# Altium schematic sheet 07 - BANK 0, BANK13, JTAG.SchDoc("07 - BANK 0, BANK13, JTAG")
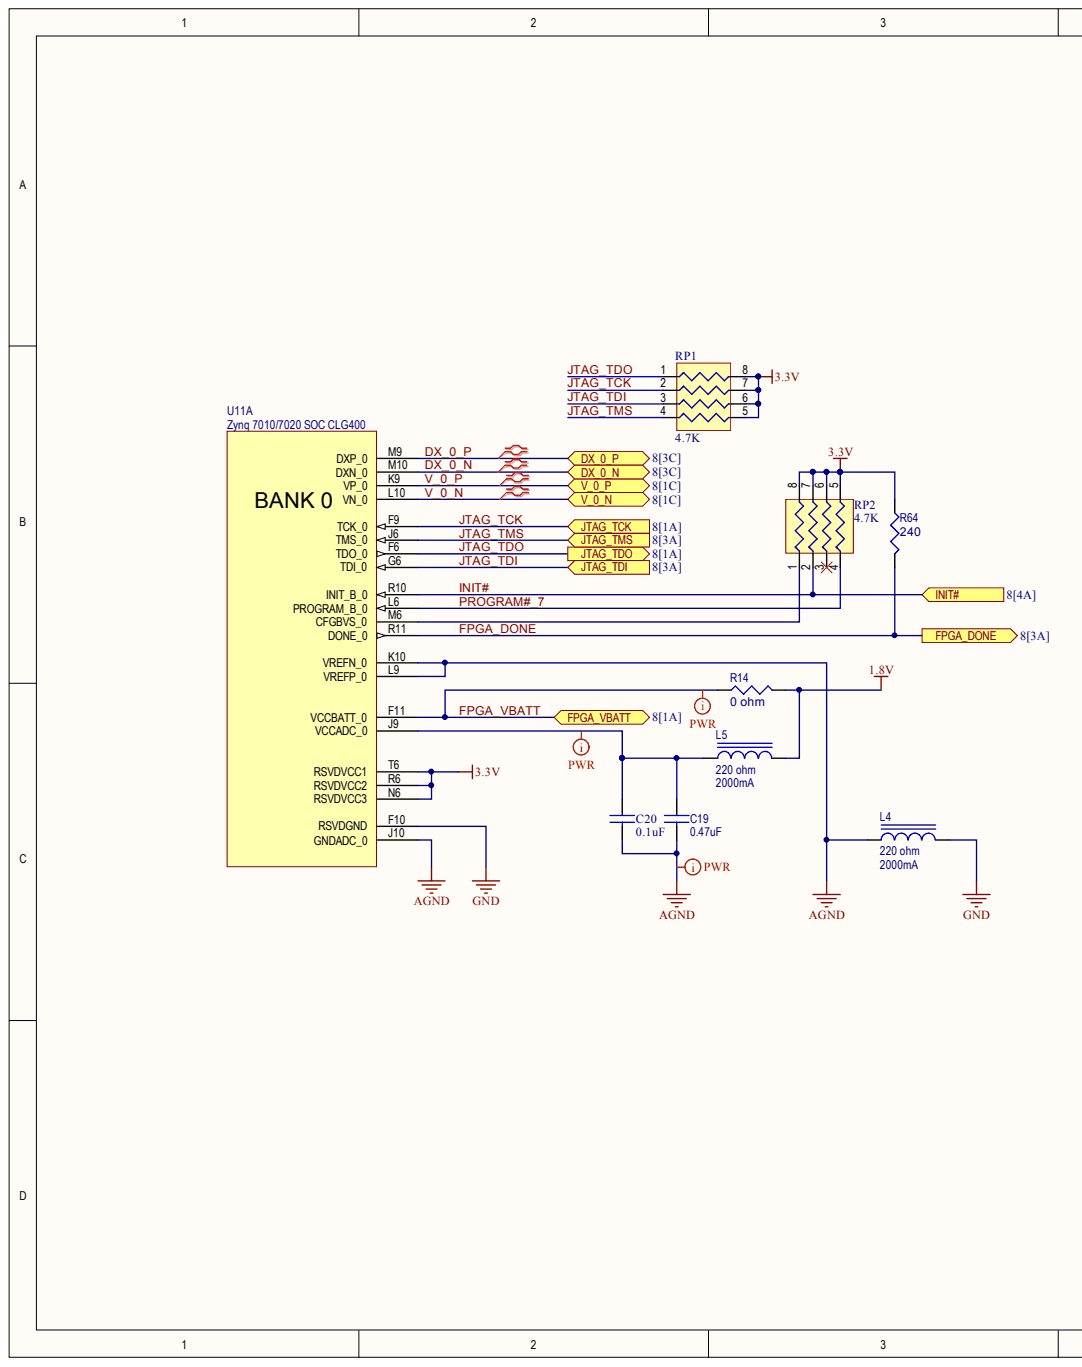
[diagram p0: 07 - BANK 0, BANK13, JTAG.SchDoc, left]
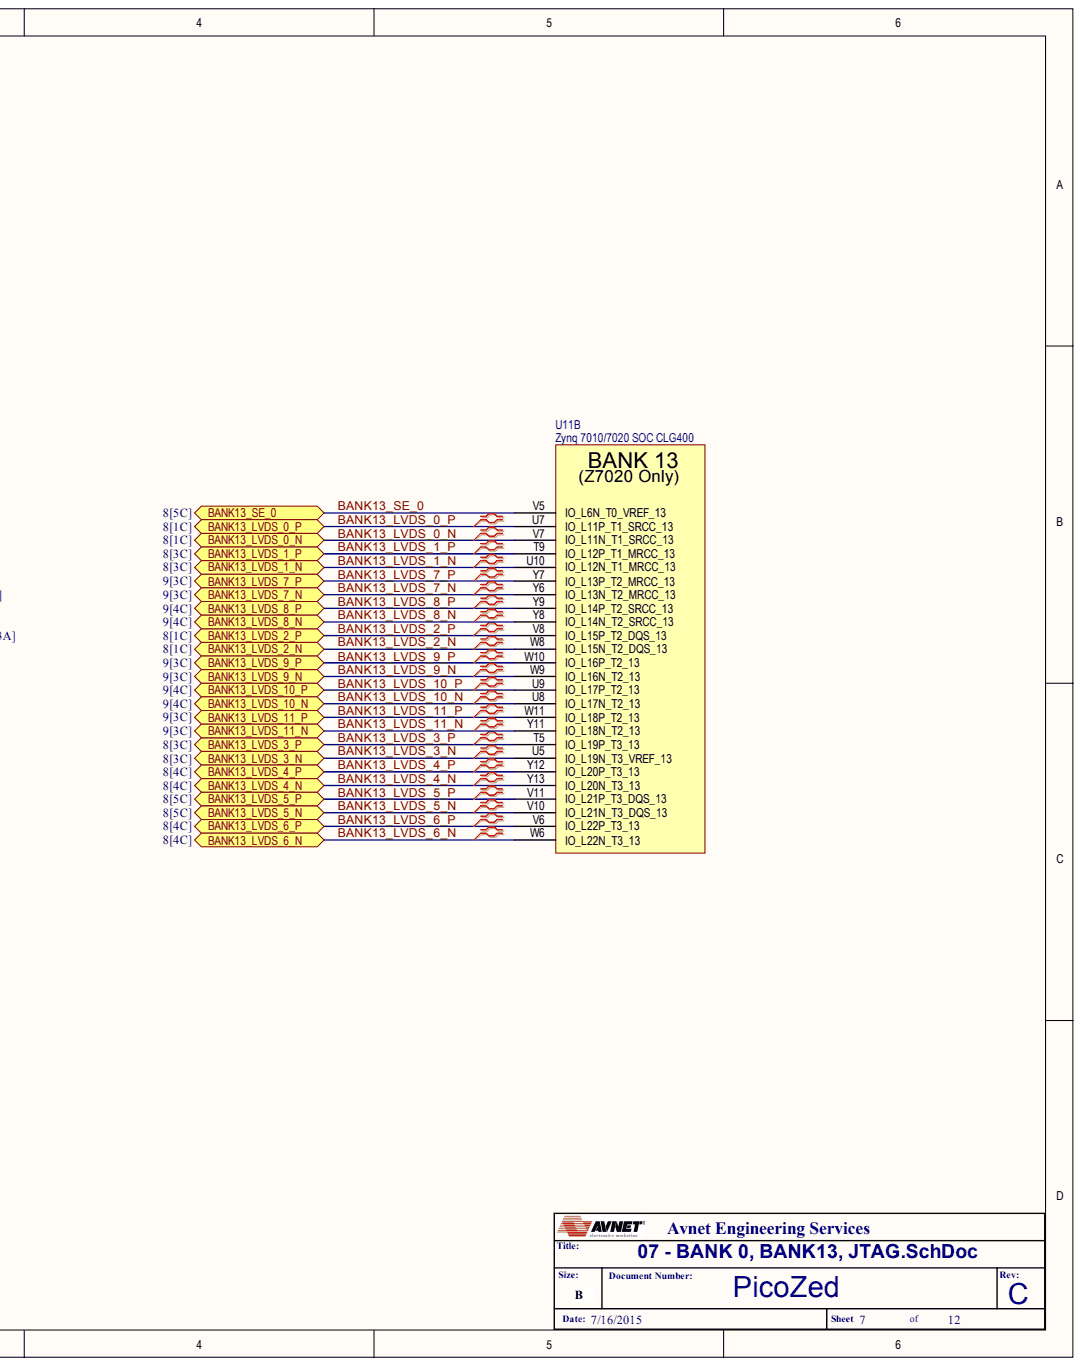
[diagram p1: 07 - BANK 0, BANK13, JTAG.SchDoc, right]

## Parts
C19  value 0.47uF  [C0402]  (hidden comment: PC475)
C20  value 0.1uF  [C0201]  (hidden comment: PC522)
L4  value 220 ohm  Max I: 2000mA  [L0805]  (hidden comment: PF009)
L5  value 220 ohm  Max I: 2000mA  [L0805]  (hidden comment: PF009)
R14  0 ohm  [R0402]
R64  240  [R0402]
RP1  value 4.7K  [RPACK4-LCC]  (hidden comment: PR010)
RP2  value 4.7K  [RPACK4-LCC]  (hidden comment: PR010)
U11  Zynq 7010/7020 SOC CLG400  [BGA400C80P20X20_1700X1700X160]  (parts A,B)

## Ports
BANK13_LVDS_0_N, BANK13_LVDS_0_P, BANK13_LVDS_1_N, BANK13_LVDS_1_P, BANK13_LVDS_2_N, BANK13_LVDS_2_P, BANK13_LVDS_3_N, BANK13_LVDS_3_P, BANK13_LVDS_4_N, BANK13_LVDS_4_P, BANK13_LVDS_5_N, BANK13_LVDS_5_P, BANK13_LVDS_6_N, BANK13_LVDS_6_P, BANK13_LVDS_7_N, BANK13_LVDS_7_P, BANK13_LVDS_8_N, BANK13_LVDS_8_P, BANK13_LVDS_9_N, BANK13_LVDS_9_P, BANK13_LVDS_10_N, BANK13_LVDS_10_P, BANK13_LVDS_11_N, BANK13_LVDS_11_P, BANK13_SE_0, DX_0_N, DX_0_P, FPGA_DONE, FPGA_VBATT, INIT#, JTAG_TCK, JTAG_TDI, JTAG_TDO, JTAG_TMS, V_0_N, V_0_P

## Nets
1.8V: L5.2 R14.2
3.3V: R64.1 RP1.5 RP1.6 RP1.7 RP1.8 RP2.5 RP2.6 RP2.7 RP2.8 U11.N6(RSVDVCC3) U11.R6(RSVDVCC2) U11.T6(RSVDVCC1)
AGND: C19.2 C20.2 L4.1 U11.J10(GNDADC_0) U11.K10(VREFN_0) U11.L9(VREFP_0)
GND: L4.2 U11.F10(RSVDGND)
BANK13_LVDS_0_N: U11.V7(IO_L11N_T1_SRCC_13) ; port BANK13_LVDS_0_N
BANK13_LVDS_0_P: U11.U7(IO_L11P_T1_SRCC_13) ; port BANK13_LVDS_0_P
BANK13_LVDS_1_N: U11.U10(IO_L12N_T1_MRCC_13) ; port BANK13_LVDS_1_N
BANK13_LVDS_1_P: U11.T9(IO_L12P_T1_MRCC_13) ; port BANK13_LVDS_1_P
BANK13_LVDS_2_N: U11.W8(IO_L15N_T2_DQS_13) ; port BANK13_LVDS_2_N
BANK13_LVDS_2_P: U11.V8(IO_L15P_T2_DQS_13) ; port BANK13_LVDS_2_P
BANK13_LVDS_3_N: U11.U5(IO_L19N_T3_VREF_13) ; port BANK13_LVDS_3_N
BANK13_LVDS_3_P: U11.T5(IO_L19P_T3_13) ; port BANK13_LVDS_3_P
BANK13_LVDS_4_N: U11.Y13(IO_L20N_T3_13) ; port BANK13_LVDS_4_N
BANK13_LVDS_4_P: U11.Y12(IO_L20P_T3_13) ; port BANK13_LVDS_4_P
BANK13_LVDS_5_N: U11.V10(IO_L21N_T3_DQS_13) ; port BANK13_LVDS_5_N
BANK13_LVDS_5_P: U11.V11(IO_L21P_T3_DQS_13) ; port BANK13_LVDS_5_P
BANK13_LVDS_6_N: U11.W6(IO_L22N_T3_13) ; port BANK13_LVDS_6_N
BANK13_LVDS_6_P: U11.V6(IO_L22P_T3_13) ; port BANK13_LVDS_6_P
BANK13_LVDS_7_N: U11.Y6(IO_L13N_T2_MRCC_13) ; port BANK13_LVDS_7_N
BANK13_LVDS_7_P: U11.Y7(IO_L13P_T2_MRCC_13) ; port BANK13_LVDS_7_P
BANK13_LVDS_8_N: U11.Y8(IO_L14N_T2_SRCC_13) ; port BANK13_LVDS_8_N
BANK13_LVDS_8_P: U11.Y9(IO_L14P_T2_SRCC_13) ; port BANK13_LVDS_8_P
BANK13_LVDS_9_N: U11.W9(IO_L16N_T2_13) ; port BANK13_LVDS_9_N
BANK13_LVDS_9_P: U11.W10(IO_L16P_T2_13) ; port BANK13_LVDS_9_P
BANK13_LVDS_10_N: U11.U8(IO_L17N_T2_13) ; port BANK13_LVDS_10_N
BANK13_LVDS_10_P: U11.U9(IO_L17P_T2_13) ; port BANK13_LVDS_10_P
BANK13_LVDS_11_N: U11.Y11(IO_L18N_T2_13) ; port BANK13_LVDS_11_N
BANK13_LVDS_11_P: U11.W11(IO_L18P_T2_13) ; port BANK13_LVDS_11_P
BANK13_SE_0: U11.V5(IO_L6N_T0_VREF_13) ; port BANK13_SE_0
DX_0_N: U11.M10(DXN_0) ; port DX_0_N
DX_0_P: U11.M9(DXP_0) ; port DX_0_P
FPGA_DONE: R64.2 U11.R11(DONE_0) ; port FPGA_DONE
FPGA_VBATT: R14.1 U11.F11(VCCBATT_0) ; port FPGA_VBATT
INIT#: RP2.2 U11.R10(INIT_B_0) ; port INIT#
JTAG_TCK: RP1.2 U11.F9(TCK_0) ; port JTAG_TCK
JTAG_TDI: RP1.3 U11.G6(TDI_0) ; port JTAG_TDI
JTAG_TDO: RP1.1 U11.F6(TDO_0) ; port JTAG_TDO
JTAG_TMS: RP1.4 U11.J6(TMS_0) ; port JTAG_TMS
PROGRAM#_7: RP2.4 U11.L6(PROGRAM_B_0)  [also labelled PROGRAM#]
V_0_N: U11.L10(VN_0) ; port V_0_N
V_0_P: U11.K9(VP_0) ; port V_0_P
NetC19_1: C19.1 C20.1 L5.1 U11.J9(VCCADC_0)
NetRP2_1: RP2.1 U11.M6(CFGBVS_0)

Not connected: RP2 3

## Library data of the parts
C19 = AVPC-0000475: CAP SM 0.47uF 6.3V 10% X5R C0402; PartCode: PC; AVLNo: AVPC-0000529; Database Table Name: Passive-Cap$; MFG Code: TAI; MFGPN: JMK105BJ474KV-F/BKN; AsyTxt: PC475; Component Kind: Standard; ComponentLink1Description: Datasheet; ComponentLink2Description: MFR Website; Device: CAP; Dielectric: X5R; ESR: CAP; PkgType: SM; RoHS: Yes; Status: Preliminary; Tol: 10%; Volt: 6.3V; ComponentLink1URL: C:\AVDBLIB\DATASHEETS\; Library Name: Avnet_DBlib.DbLib
C20 = AVPC-0000522: CAP SM 0.1uF 10V 10% X5R C0201; PartCode: PC; Database Table Name: Passive-Cap$; MFG Code: AVX; MFGPN: 02016D104KAT2A; AsyTxt: PC522; AVLNo: AVPC-0000522; CLASSNAME: U11_BYP; Component Kind: Standard; ComponentLink1Description: Datasheet; Device: CAP; Dielectric: X5R; PkgType: SM; RoHS: Yes; Status: Preliminary; Tol: 10%; Volt: 10V; ComponentLink1URL: C:\AVDBLIB\DATASHEETS\AVX_CCOG.pdf; Library Name: Avnet_DBlib.DbLib
L4 L5 = AVPF-0000009: FB SM 220 ohm@100MHz 2000mA 0.25 0.045 ohm@DC L0805; PartCode: PF; Database Table Name: Passive-Ferrite Bead$; MFG Code: MUR; MFGPN: BLM21PG221SN1D; Test Freq: 100MHz; Tol: 0.25; AsyTxt: PF009; AVLNo: AVPF-0000009; Component Kind: Standard; ComponentLink1Description: Datasheet; DCR: 0.045 ohm; Device: FB; PkgType: SM; RoHS: Yes; Status: Preliminary; ComponentLink1URL: C:\AVDBLIB\DATASHEETS\L0110S0100BLM21P.pdf; Library Name: Avnet_DBlib.DbLib
R14 = AVPR-0000027: RES SM 0 ohm 62mW J R0402; PartCode: PR; AsyTxt: PR027; Database Table Name: Passive-Res$; MFG Code: DAL; MFGPN: CRCW04020000Z0ED; AVLNo: AVPR-0000027; Component Kind: Standard; ComponentLink1Description: Datasheet; Device: RES; PkgType: SM; RoHS: Yes; Status: Preliminary; Value: 0 ohm; Watt: 62mW; ComponentLink1URL: C:\AVDBLIB\DATASHEETS\Dale_CRCW.pd; Library Name: Avnet_DBlib.DbLib
R64 = AVPR-0000511: RES SM 240 62mW 0.01 R0402; Alt1MFR: NIC; PartCode: PR; Alt1PN: NRC04F2400TRF; AsyTxt: PR511; Database Table Name: Passive-Res$; MFG Code: DAL; MFGPN: CRCW0402240RFKED; Power: 0; Tol: 0.01; Availability: 1M-AV; AVLNo: AVPR-0000511; Component Kind: Standard; ComponentLink1Description: Datasheet; Cost: .01; Device: RES; PkgType: SM; Received: 0; RoHS: Yes; Size: 1.9; Status: Preliminary; Value: 240; Watt: 62mW; ComponentLink1URL: C:\AVDBLIB\DATASHEETS\Dale_CRCW.pd; Library Name: Avnet_DBlib.DbLib
RP1 RP2 = AVPR-0000010: RPACK SM 4.7K 62mW 0.05 RPACK4-LCC; PartCode: PR; Database Table Name: Passive-Res$; MFG Code: BNS; MFGPN: CAT16-472J4LF; Tol: 0.05; AsyTxt: PR010; AVLNo: AVPR-0000010; Component Kind: Standard; ComponentLink1Description: Datasheet; Device: RPACK; PkgType: SM; RoHS: Yes; Status: Preliminary; Watt: 62mW; ComponentLink1URL: C:\AVDBLIB\DATASHEETS\CAT_CAY.pdf; Library Name: Avnet_DBlib.DbLib
U11 = Zynq Z010/Z020 SOC CLG400: AVLNo: AVIC-0000420; MFG Code: XLX; MFGPN: XC7Z010-1CLG400C; CLASSNAME: BGA; Component Kind: Standard; Description: Zynq 7010/7020 SOC CLG400; Library Name: Zynq_Z010_ZedStick.SchLib
